# Altium schematic sheet mcu.SchDoc
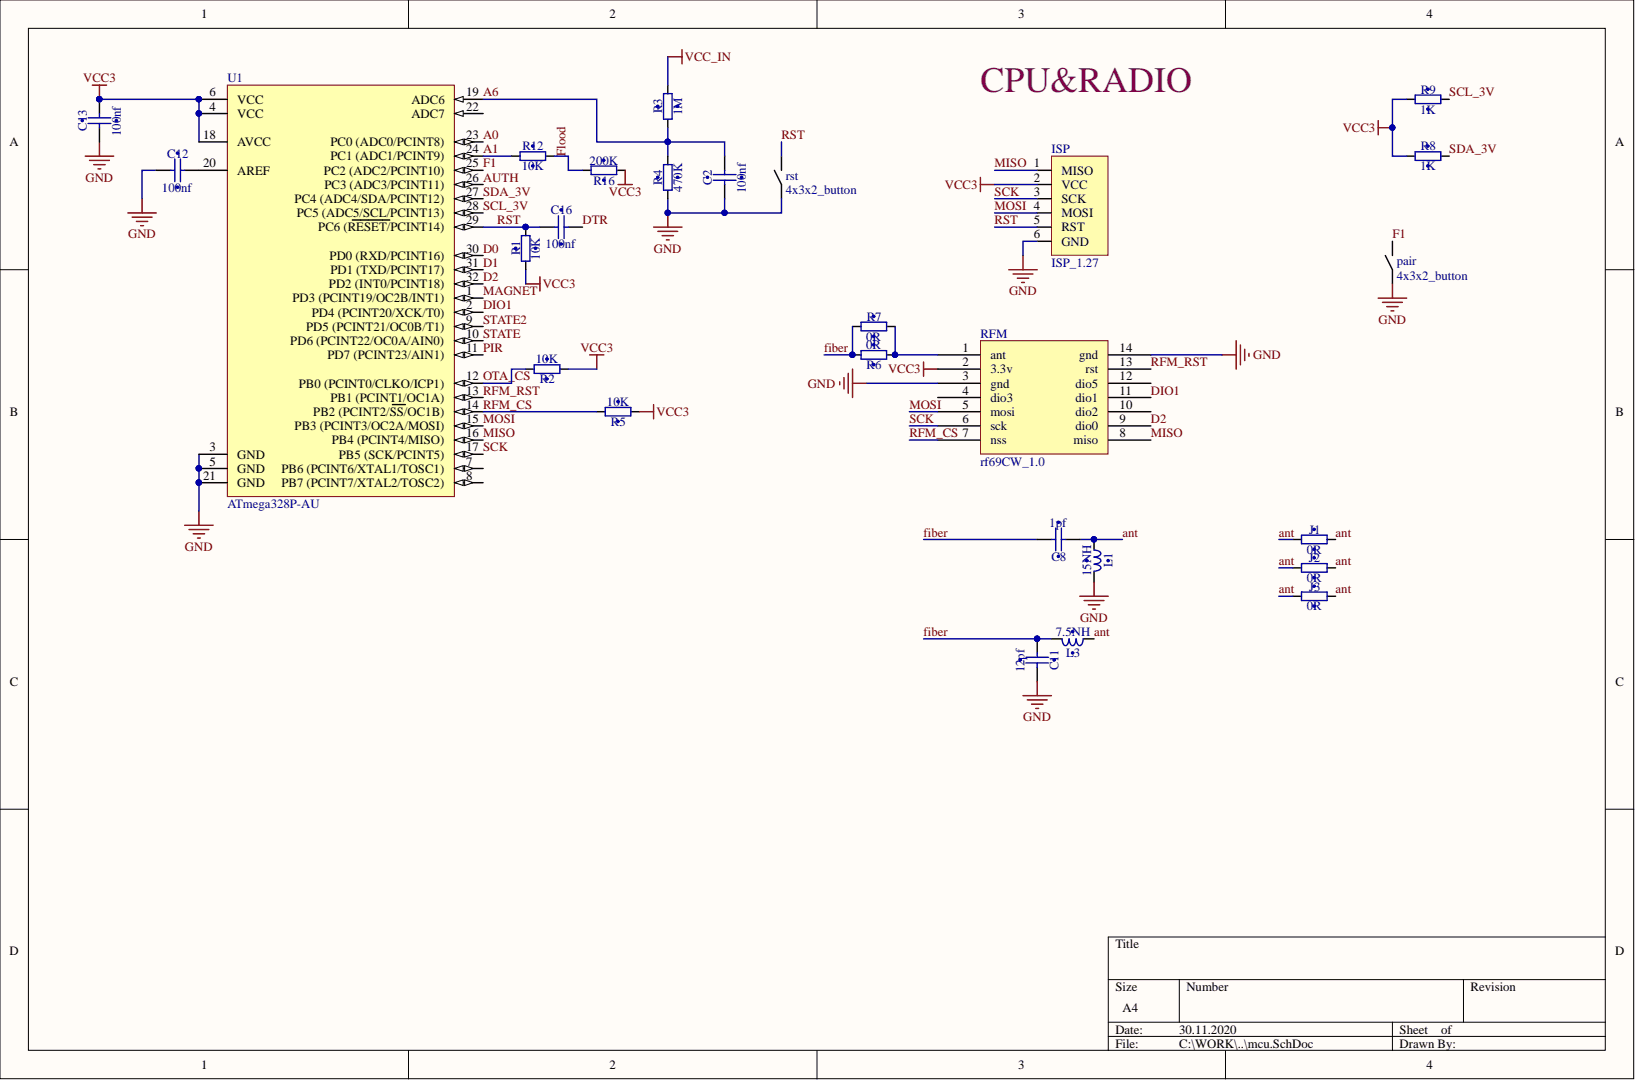
[diagram p0: mcu.SchDoc]

## Parts
C2  100nf  [CAP_0603]
C8  1pf  [CAP_0402]
C11  12pf  [CAP_0402]
C12  100nf  [CAP_0603]
C13  100nf  [CAP_0603]
C16  100nf  [CAP_0603]
ISP  ISP_1.27  [ISP_1.27]  (no BOM)
J1  0R  [RES_0402]
J2  0R  [RES_0402]
J3  0R  [RES_0402]
L1  15NH  [INDC1005X55N_O]
L3  7.5NH  [INDC1005X55N_O]
pair  4x3x2_button  [4x3x2_button]
R1  10K  [RES_0603]
R2  10K  [RES_0603]
R3  1M  [RES_0603]
R4  470K  [RES_0603]
R5  10K  [RES_0603]
R6  0R  [RES_0402]
R7  0R  [RES_0402]
R8  1K  [RES_0603]
R9  1K  [RES_0603]
R12  10K  [RES_0402]
R16  200K  [RES_0402]
RFM  rf69CW_1.0  [RF69_COMBO_DIO1]
rst  4x3x2_button  [4x3x2_button]
U1  ATmega328P-AU  [TQFP32]

## Nets
GND: C2.1 C11.2 C12.1 C13.1 ISP.6(GND) L1.2 pair.1 R4.1 RFM.3(gnd) RFM.14(gnd) rst.1 U1.3(GND) U1.5(GND) U1.21(GND)
VCC3: C13.2 ISP.2(VCC) R1.1 R2.1 R5.1 R8.1 R9.1 R16.1 RFM.2(3.3v) U1.4(VCC) U1.6(VCC) U1.18(AVCC)
VCC_IN: R3.2
A0: U1.23(PC0_(ADC0/PCINT8))
A1: R12.1 U1.24(PC1_(ADC1/PCINT9))
A6: C2.2 R3.1 R4.2 U1.19(ADC6)
ANT: C8.1 J1.1 J1.2 J2.1 J2.2 J3.1 J3.2 L1.1 L3.1
AUTH: U1.26(PC3_(ADC3/PCINT11))
D0: U1.30(PD0_(RXD/PCINT16))
D1: U1.31(PD1_(TXD/PCINT17))
D2: RFM.9(dio0) U1.32(PD2_(INT0/PCINT18))
DIO1: RFM.11(dio1) U1.2(PD4_(PCINT20/XCK/T0))
DTR: C16.2
F1: pair.2 U1.25
FIBER: C8.2 C11.1 L3.2 R6.2 R7.1
FLOOD: R12.2 R16.2
MAGNET: U1.1(PD3_(PCINT19/OC2B/INT1))
MISO: ISP.1(MISO) RFM.8(miso) U1.16(PB4_(PCINT4/MISO))
MOSI: ISP.4(MOSI) RFM.5(mosi) U1.15(PB3_(PCINT3/OC2A/MOSI))
OTA_CS: R2.2 U1.12(PB0_(PCINT0/CLKO/ICP1))
PIR: U1.11(PD7_(PCINT23/AIN1))
RFM_CS: R5.2 RFM.7(nss) U1.14(PB2_(PCINT2/SS/OC1B))
RFM_RST: RFM.13(rst) U1.13(PB1_(PCINT1/OC1A))
RST: C16.1 ISP.5(RST) R1.2 rst.2 U1.29(PC6_(RESET/PCINT14))
SCK: ISP.3(SCK) RFM.6(sck) U1.17(PB5_(SCK/PCINT5))
SCL_3V: R9.2 U1.28(PC5_(ADC5/SCL/PCINT13))
SDA_3V: R8.2 U1.27(PC4_(ADC4/SDA/PCINT12))
STATE: U1.10(PD6_(PCINT22/OC0A/AIN0))
STATE2: U1.9(PD5_(PCINT21/OC0B/T1))
NetC12_2: C12.2 U1.20(AREF)
NetR6_1: R6.1 R7.2 RFM.1(ant)

Not connected: RFM 4(dio3) 10(dio2) 12(dio5); U1 7 8 22(ADC7)

## Library data of the parts
C2 C12 C13 C16 = C0603_100NF: Non-polarised capacitor; Database Table Name: CAP; GoodsBriefName: RC_C_0603_100NF_1PCS; GoodsID: 47802; Код: 2; Case: 0603; Component Kind: Standard; Part Number: C0603_100NF; Pin Count: 2; Value: 100nf; Library Name: MIPS_COMPONENTS_LIB.DbLib
C8 = C0402_1PF: Non-polarised capacitor; Database Table Name: CAP; Код: 31; Case: 0402; Component Kind: Standard; Part Number: C0402_1PF; Pin Count: 2; Value: 1pf; Library Name: MIPS_COMPONENTS_LIB.DbLib
C11 = C0402_12PF: Non-polarised capacitor; Database Table Name: CAP; Код: 33; Case: 0402; Component Kind: Standard; Part Number: C0402_12PF; Pin Count: 2; Value: 12pf; Library Name: MIPS_COMPONENTS_LIB.DbLib
J1-J3 R6 R7 R12 R16 = R0402_0R_1%: Resistor; Database Table Name: res; GoodsBriefName: RC_R_0402_0OM; GoodsID: 59788; Код: 23; Case: 0402; Component Kind: Standard; Part Number: R0402_0R_1%; Pin Count: 2; Library Name: MIPS_COMPONENTS_LIB.DbLib
  J1-J3 R6 R7: Value: 0R
  R12: Value: 10K
  R16: Value: 200K
L1 = IND_15NH: Inductor; Database Table Name: IND; Код: 7; Case: 0402; Component Kind: Standard; Part Number: IND_15NH; Pin Count: 2; Value: 15NH; Library Name: MIPS_COMPONENTS_LIB.DbLib
L3 = IND_7.5NH: Inductor; Database Table Name: IND; Код: 8; Case: 0402; Component Kind: Standard; Part Number: IND_7.5NH; Pin Count: 2; Value: 7.5NH; Library Name: MIPS_COMPONENTS_LIB.DbLib
pair rst = SW_4x3x2: Database Table Name: MISC; GoodsBriefName: RC_SW_1KEY_BUTTON_SMD_3X4X2MM_2PIN; GoodsID: 59502; Код: 11; Case: 4x3x2; Component Kind: Standard; Part Number: SW_4x3x2; Pin Count: 2; Value: 4x3x2_button; Library Name: MIPS_COMPONENTS_LIB.DbLib
R1 R2 R5 = R0603_10K_1%: Resistor; Database Table Name: res; GoodsBriefName: RC_R_0603_10KOM_1PERCENT_1PCS; GoodsID: 59406; Код: 10; Case: 0603; Component Kind: Standard; Part Number: R0603_10K_1%; Pin Count: 2; Value: 10K; Library Name: MIPS_COMPONENTS_LIB.DbLib
R3 = R0603_1M_1%: Resistor; Database Table Name: res; GoodsBriefName: RC_R_0603_1MOM_1PERCENT_1PCS; GoodsID: 59840; Код: 24; Case: 0603; Component Kind: Standard; Part Number: R0603_1M_1%; Pin Count: 2; Value: 1M; Library Name: MIPS_COMPONENTS_LIB.DbLib
R4 = R0603_470K_1%: Resistor; Database Table Name: res; GoodsBriefName: RC_R_0603_470KOM_1PERCENT; GoodsID: 60645; Код: 25; Case: 0603; Component Kind: Standard; Part Number: R0603_470K_1%; Pin Count: 2; Value: 470K; Library Name: MIPS_COMPONENTS_LIB.DbLib
R8 R9 = R0603_1K_1%: Resistor; Database Table Name: res; GoodsBriefName: RC_R_0603_1KOM_1PERCENT_1PCS; GoodsID: 59407; Код: 8; Case: 0603; Component Kind: Standard; Part Number: R0603_1K_1%; Pin Count: 2; Value: 1K; Library Name: MIPS_COMPONENTS_LIB.DbLib
RFM = MODULE_RFM69: Database Table Name: MODULES; Код: 5; Case: board; Component Kind: Standard; Part Number: MODULE_RFM69; Pin Count: 10; Value: rf69CW_1.0; Library Name: MIPS_COMPONENTS_LIB.DbLib
U1 = IC_ATMEGA328P-AU: 8-bit AVR Microcontroller, 32KB Flash, 1KB EEPROM, 2KB SRAM, 32-pin TQFP, Industrial Grade (-40°C to 85°C); Database Table Name: IC; GoodsBriefName: RC_IC_ATMEGA328P-AU_TQFP32; GoodsID: 50712; Код: 16; Case: TQFP32; Code_JEDEC: MS-026-ABA; Component Kind: Standard; ComponentLink1Description: Manufacturer URL; ComponentLink1URL: http://www.atmel.com/; ComponentLink2Description: ATmega48A/48PA/88A/88PA/168A/168PA/328/328P Datasheet; ComponentLink2URL: http://www.atmel.com/dyn/resources/prod_documents/doc8271.pdf; ComponentLink3Description: ATmega48A/48PA/88A/88PA/168A/168PA/328/328P Summary; ComponentLink3URL: http://www.atmel.com/dyn/resources/prod_documents/8271S.pdf; DatasheetVersion: revD, May-2011; PackageDescription: 32-Lead, 7x7x1.0 mm Body, 0.8mm Lead Pitch, Thin Profile Plastic Quad Flat Package (TQFP); PackageReference: 32A; PackageVersion: revC, Oct-2010; Part Number: IC_ATMEGA328P-AU; Pin Count: 32; Value: ATMEGA328P-AU; Library Name: MIPS_COMPONENTS_LIB.DbLib
ISP = ISP_1.27: Library Name: rf69.SchLib
